# Altium schematic sheet DEVICE.SchDoc(DEVICE)
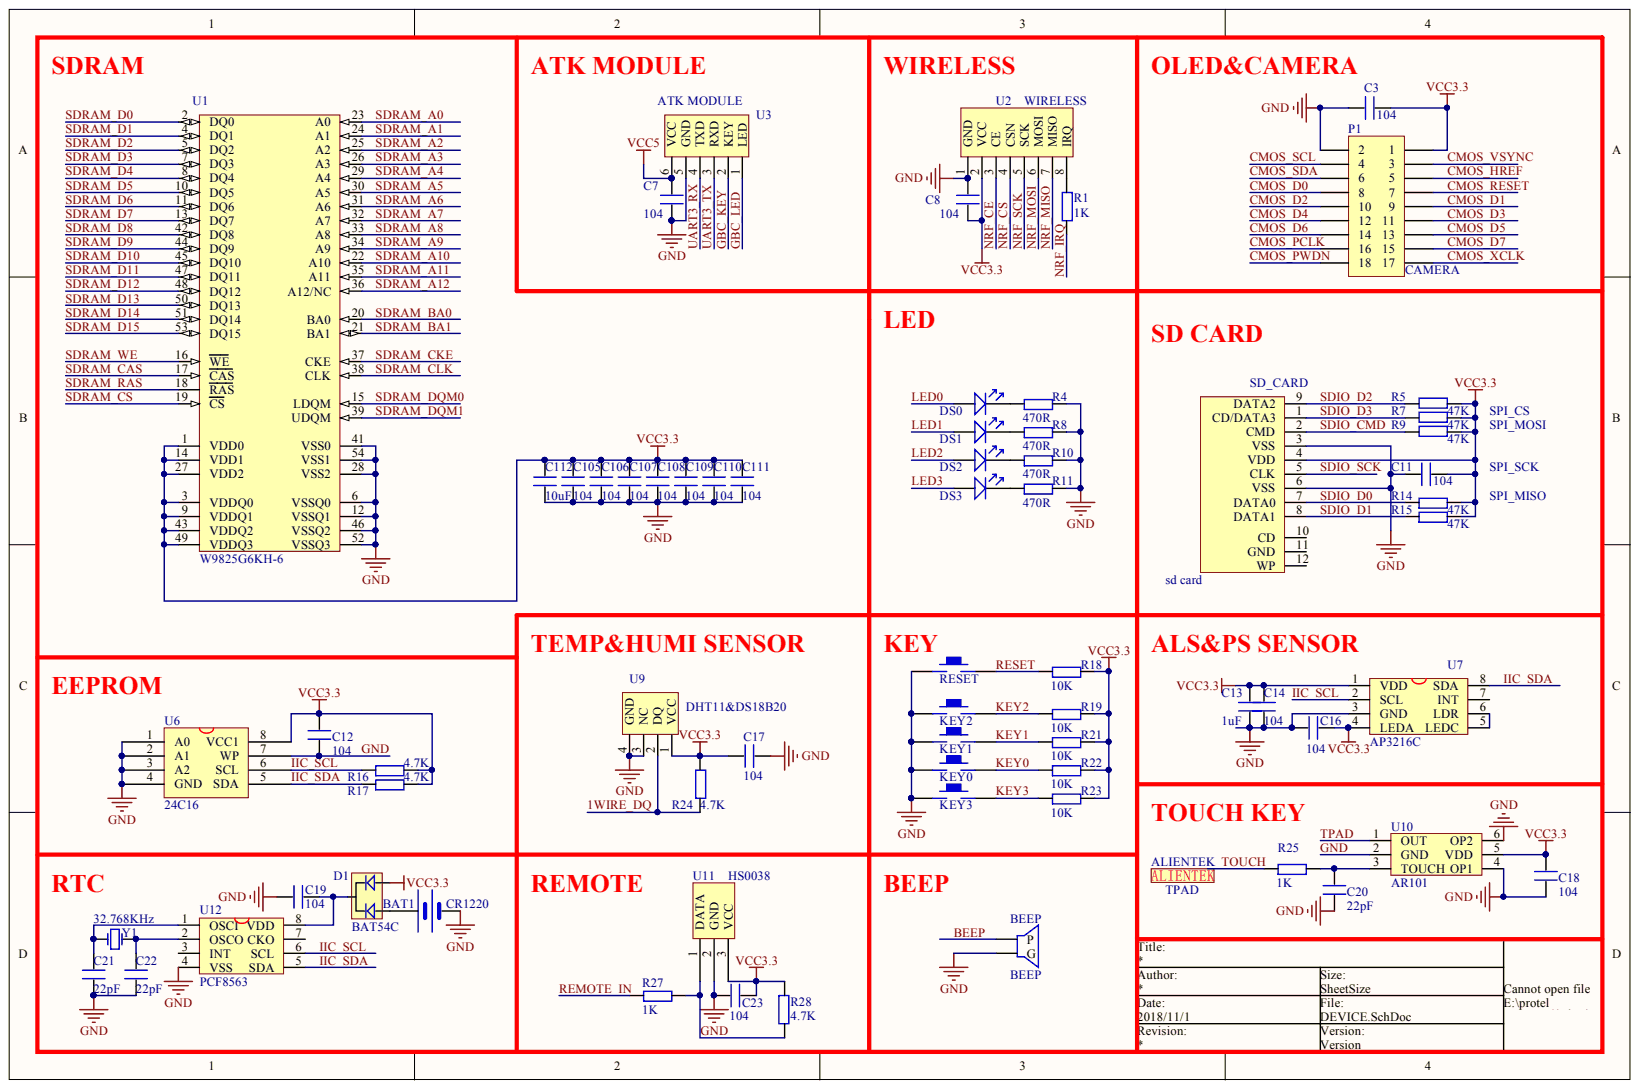
[diagram p0: DEVICE.SchDoc]

## Parts
BAT1  CR1220  [BATM]
BEEP  BEEP  [BELL-5]
C3  104  [0603P]
C7  104  [0603P]
C8  104  [0603P]
C11  104  [0603P]
C12  104  [0603P]
C13  1uF  [0603P]
C14  104  [0603P]
C16  104  [0603P]
C17  104  [0603P]
C18  104  [0603P]
C19  104  [0603P]
C20  22pF  [0603P]
C21  22pF  [0603P]
C22  22pF  [0603P]
C23  104  [0603P]
C105  104  [0603P]
C106  104  [0603P]
C107  104  [0603P]
C108  104  [0603P]
C109  104  [0603P]
C110  104  [0603P]
C111  104  [0603P]
C112  10uF  [0805]
D1  BAT54C  [SOT-23]
KEY0  KEY_M  [KEY_SOP324225]  (value not drawn)
KEY1  KEY_M  [KEY_SOP324225]  (value not drawn)
KEY2  KEY_M  [KEY_SOP324225]  (value not drawn)
KEY3  KEY_M  [KEY_SOP324225]  (value not drawn)
LED1  DS0  [SMDLED-0805]
LED2  DS1  [SMDLED-0805]
LED3  DS2  [SMDLED-0805]
LED4  DS3  [SMDLED-0805]
P1  CAMERA  [HDR2X9]
R1  1K  [0603P]
R4  470R  [0603P]
R5  47K  [0603P]
R7  47K  [0603P]
R8  470R  [0603P]
R9  47K  [0603P]
R10  470R  [0603P]
R11  470R  [0603P]
R14  47K  [0603P]
R15  47K  [0603P]
R16  4.7K  [0603P]
R17  4.7K  [0603P]
R18  10K  [0603P]
R19  10K  [0603P]
R21  10K  [0603P]
R22  10K  [0603P]
R23  10K  [0603P]
R24  4.7K  [0603P]
R25  1K  [0603P]
R27  1K  [0603P]
R28  4.7K  [0603P]
RESET  KEY_M  [KEY_SOP324225]  (value not drawn)
SD_CARD  sd card  [SDCARD_L]
TPAD  ALIENTEK  [ALIENTEK_PAD1]
U1  W9825G6KH-6  [TSOP54]
U2  WIRELESS  [NRF24L01]
U3  ATK MODULE  [HEAD6]
U6  24C16  [SOP-8]
U7  AP3216C  [QFN8]
U9  DHT11&DS18B20  [DHT11]
U10  AR101  [SOT-23-6]
U11  HS0038  [HS0038]
U12  PCF8563  [SOP-8]
Y1  32.768KHz  [XTAL32]

## Nets
VCC3.3: C3.1 C8.2 C11.2 C12.2 C13.1 C14.1 C16.2 C17.1 C18.1 C23.1 C105.2 C106.2 C107.2 C108.2 C109.2 C110.2 C111.2 C112.1 D1.2 P1.1 R5.1 R7.1 R9.1 R14.2 R15.2 R16.2 R17.2 R18.2 R19.2 R21.2 R22.2 R23.2 R24.2 R28.1 SD_CARD.4(VDD) U1.1(VDD0) U1.3(VDDQ0) U1.9(VDDQ1) U1.14(VDD1) U1.27(VDD2) U1.43(VDDQ2) U1.49(VDDQ3) U2.2(VCC) U6.8(VCC1) U7.1(VDD) U7.4(LEDA) U9.1(VCC) U10.5(VDD) U11.3
VCC5: C7.1 U3.6(VCC)
1WIRE_DQ: R24.1 U9.2(DQ)
BEEP: BEEP.1
CMOS_D0: P1.8
CMOS_D1: P1.9
CMOS_D2: P1.10
CMOS_D3: P1.11
CMOS_D4: P1.12
CMOS_D5: P1.13
CMOS_D6: P1.14
CMOS_D7: P1.15
CMOS_HREF: P1.5
CMOS_PCLK: P1.16
CMOS_PWDN: P1.18
CMOS_RESET: P1.7
CMOS_SCL: P1.4
CMOS_SDA: P1.6
CMOS_VSYNC: P1.3
CMOS_XCLK: P1.17
GBC_KEY: U3.2(KEY)
GBC_LED: U3.1(LED)
GND: BAT1.2 BEEP.2 C3.2 C7.2 C8.1 C11.1 C12.1 C13.2 C14.2 C16.1 C17.2 C18.2 C19.2 C20.1 C21.2 C22.2 C23.2 C105.1 C106.1 C107.1 C108.1 C109.1 C110.1 C111.1 C112.2 KEY0.1 KEY1.1 KEY2.1 KEY3.1 P1.2 R4.2 R8.2 R10.2 R11.2 RESET.1 SD_CARD.3(VSS) SD_CARD.6(VSS) U1.6(VSSQ0) U1.12(VSSQ1) U1.28(VSS2) U1.41(VSS0) U1.46(VSSQ2) U1.52(VSSQ3) U1.54(VSS1) U2.1(GND) U3.5(GND) U6.1(A0) U6.2(A1) U6.3(A2) U6.4(GND) U6.7(WP) U7.3(GND) U9.3(NC) U9.4(GND) U10.2(GND) U10.4(OP1) U10.6(OP2) U11.2 U12.4(VSS)
IIC_SCL: R16.1 U6.6(SCL) U7.2(SCL) U12.6(SCL)
IIC_SDA: R17.1 U6.5(SDA) U7.8(SDA) U12.5(SDA)
KEY0: KEY0.2 R22.1
KEY1: KEY1.2 R21.1
KEY2: KEY2.2 R19.1
KEY3: KEY3.2 R23.1
LED0: LED1.1
LED1: LED2.1
LED2: LED3.1
LED3: LED4.1
NRF_CE: U2.3(CE)
NRF_CS: U2.4(CSN)
NRF_IRQ: R1.1
NRF_MISO: U2.7(MISO)
NRF_MOSI: U2.6(MOSI)
NRF_SCK: U2.5(SCK)
REMOTE_IN: R27.1
RESET: R18.1 RESET.2
SDIO_CMD: R9.2 SD_CARD.2(CMD)
SDIO_D0: R14.1 SD_CARD.7(DATA0)
SDIO_D1: R15.1 SD_CARD.8(DATA1)
SDIO_D2: R5.2 SD_CARD.9(DATA2)
SDIO_D3: R7.2 SD_CARD.1(CD/DATA3)
SDIO_SCK: SD_CARD.5(CLK)
SDRAM_A0: U1.23(A0)
SDRAM_A1: U1.24(A1)
SDRAM_A2: U1.25(A2)
SDRAM_A3: U1.26(A3)
SDRAM_A4: U1.29(A4)
SDRAM_A5: U1.30(A5)
SDRAM_A6: U1.31(A6)
SDRAM_A7: U1.32(A7)
SDRAM_A8: U1.33(A8)
SDRAM_A9: U1.34(A9)
SDRAM_A10: U1.22(A10)
SDRAM_A11: U1.35(A11)
SDRAM_A12: U1.36(A12/NC)
SDRAM_BA0: U1.20(BA0)
SDRAM_BA1: U1.21(BA1)
SDRAM_CAS: U1.17(CAS)
SDRAM_CKE: U1.37(CKE)
SDRAM_CLK: U1.38(CLK)
SDRAM_CS: U1.19(CS)
SDRAM_D0: U1.2(DQ0)
SDRAM_D1: U1.4(DQ1)
SDRAM_D2: U1.5(DQ2)
SDRAM_D3: U1.7(DQ3)
SDRAM_D4: U1.8(DQ4)
SDRAM_D5: U1.10(DQ5)
SDRAM_D6: U1.11(DQ6)
SDRAM_D7: U1.13(DQ7)
SDRAM_D8: U1.42(DQ8)
SDRAM_D9: U1.44(DQ9)
SDRAM_D10: U1.45(DQ10)
SDRAM_D11: U1.47(DQ11)
SDRAM_D12: U1.48(DQ12)
SDRAM_D13: U1.50(DQ13)
SDRAM_D14: U1.51(DQ14)
SDRAM_D15: U1.53(DQ15)
SDRAM_DQM0: U1.15(LDQM)
SDRAM_DQM1: U1.39(UDQM)
SDRAM_RAS: U1.18(RAS)
SDRAM_WE: U1.16(WE)
TOUCH: R25.1
TPAD: U10.1(OUT)
UART3_RX: U3.4(TXD)
UART3_TX: U3.3(RXD)
NetBAT1_1: BAT1.1 D1.1
NetC19_1: C19.1 D1.3 U12.8(VDD)
NetC20_2: C20.2 R25.2 U10.3(TOUCH)
NetC21_1: C21.1 U12.1(OSCI) Y1.2
NetC22_1: C22.1 U12.2(OSCO) Y1.1
NetLED1_2: LED1.2 R4.1
NetLED2_2: LED2.2 R8.1
NetLED3_2: LED3.2 R10.1
NetLED4_2: LED4.2 R11.1
NetR1_2: R1.2 U2.8(IRQ)
NetR27_2: R27.2 R28.2 U11.1
NetU7_5: U7.5(LEDC) U7.6(LDR)

Not connected: SD_CARD 10(CD) 11(GND) 12(WP); U7 7(INT); U12 3(INT) 7(CKO)

## Library data of the parts
BAT1 = BAT: Component Kind: Standard; Library Name: ALIENTEK_COMPONENT.IntLib
C3 C7 C8 C11-C14 C16-C23 C105-C112 = C: Component Kind: Standard
  C3 C7 C8 C11-C14 C16-C23: Library Name: MYCOMLIB.IntLib
  C105-C112: Library Name: ALIENTEK_COMPONENT.IntLib
D1 = BAT54C: 双肖特基二极管; Component Kind: Standard; Library Name: ALIENTEK_COMPONENT.IntLib
LED1-LED4 = LED: Component Kind: Standard; Library Name: ALIENTEK_COMPONENT.IntLib
P1 = Header 9X2: Header, 9-Pin, Dual row; Component Kind: Standard; LatestRevisionDate: 17-Jul-2002; LatestRevisionNote: Re-released for DXP Platform.; Library Name: Miscellaneous Connectors.IntLib; Publisher: Altium Limited
R1 R4 R5 R7-R11 R14-R19 R21-R25 R27 R28 = R: Component Kind: Standard; Library Name: MYCOMLIB.IntLib
TPAD = TPAD: ALIENTEK TPAD; Component Kind: Standard; Library Name: ALIENTEK_COMPONENT.IntLib
U1 = IS42S16160B: 32MB SDRAM; Component Kind: Standard; Library Name: ALIENTEK_COMPONENT.IntLib
U2 = NRF24L01: Component Kind: Standard; Library Name: MYCOMLIB.IntLib
U3 = ATK-HC05: Component Kind: Standard; Description: ATK-HC05; Library Name: ALIENTEK_COMPONENT.IntLib
U6 = 24C256: Component Kind: Standard; Library Name: MYCOMLIB.IntLib
U7 = AP3216C: ALS+PS Sensor; Component Kind: Standard; Library Name: ALIENTEK_COMPONENT.IntLib
U9 = DHT11: 数字温湿度传感器; Component Kind: Standard; Library Name: ALIENTEK_COMPONENT.IntLib
U10 = AR101: 单路电容触摸芯片; Component Kind: Standard; Library Name: ALIENTEK_COMPONENT.IntLib
U12 = PCF8563: 时钟芯片; Component Kind: Standard; Library Name: ALIENTEK_COMPONENT.IntLib
Y1 = XTAL: 普通晶振; Component Kind: Standard; LatestRevisionDate: 17-Jul-2002; LatestRevisionNote: Re-released for DXP Platform.; Library Name: ALIENTEK_COMPONENT.IntLib; Published: 8-Jun-2000; Publisher: Altium Limited
BEEP = BEEP: Component Kind: Standard; Library Name: ALIENTEK_COMPONENT.IntLib
KEY0-KEY3 RESET = KEY_M: Component Kind: Standard; Library Name: ALIENTEK_COMPONENT.IntLib
SD_CARD = sd card: Component Kind: Standard; Library Name: MYCOMLIB.IntLib
U11 = HS0038: Component Kind: Standard; Library Name: selfmade.SchLib
